# Altium schematic sheet [3]USB.SchDoc([3]USB)
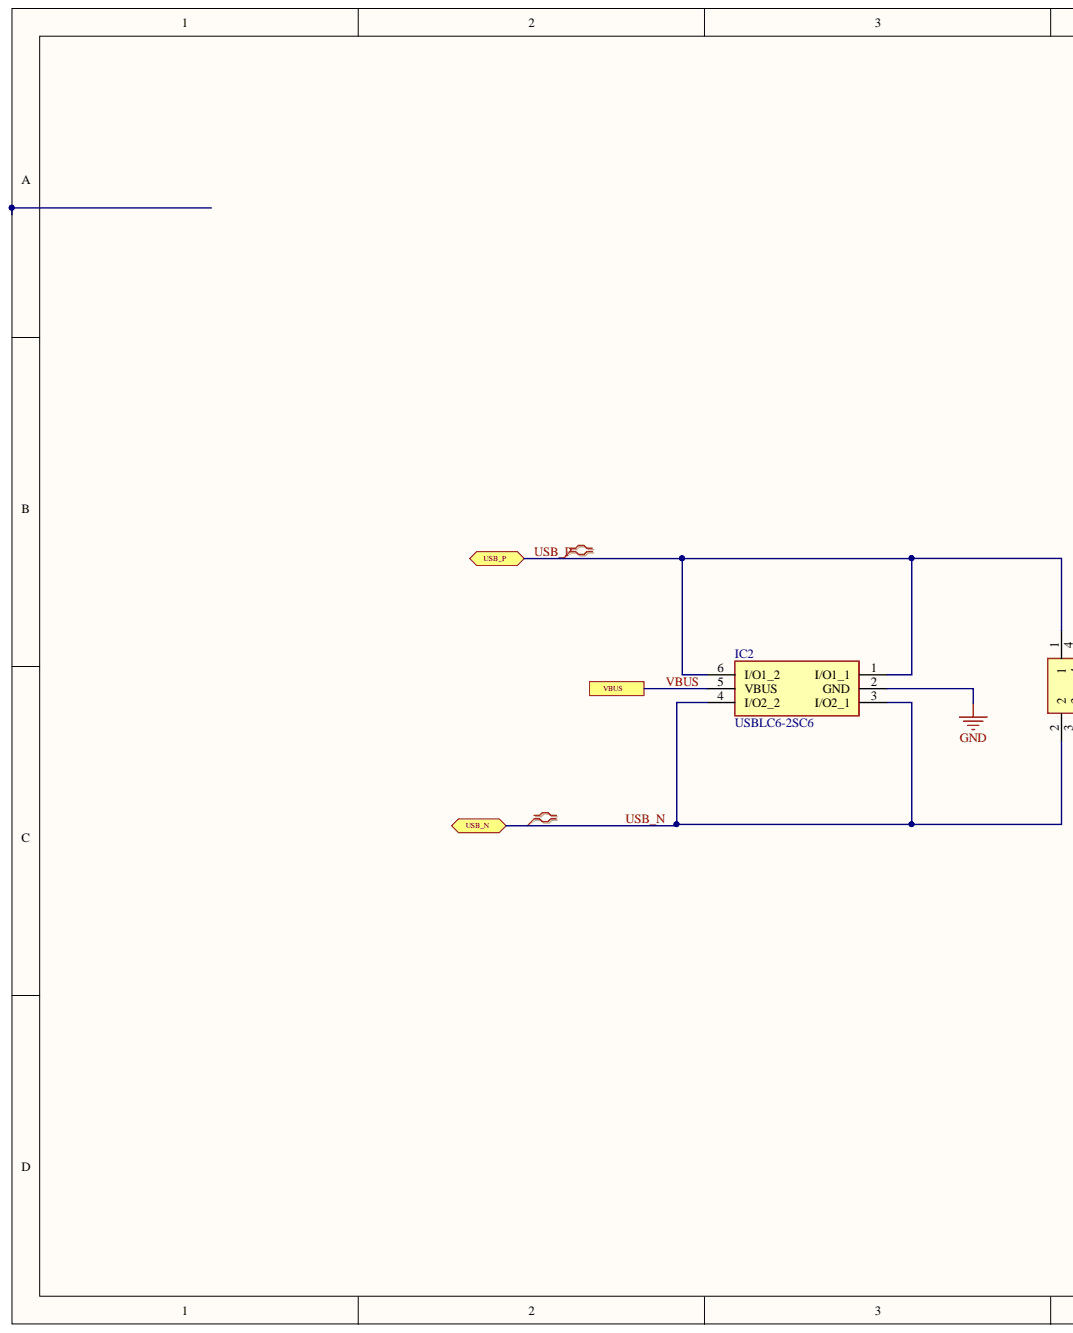
[diagram p0: [3]USB.SchDoc, left]
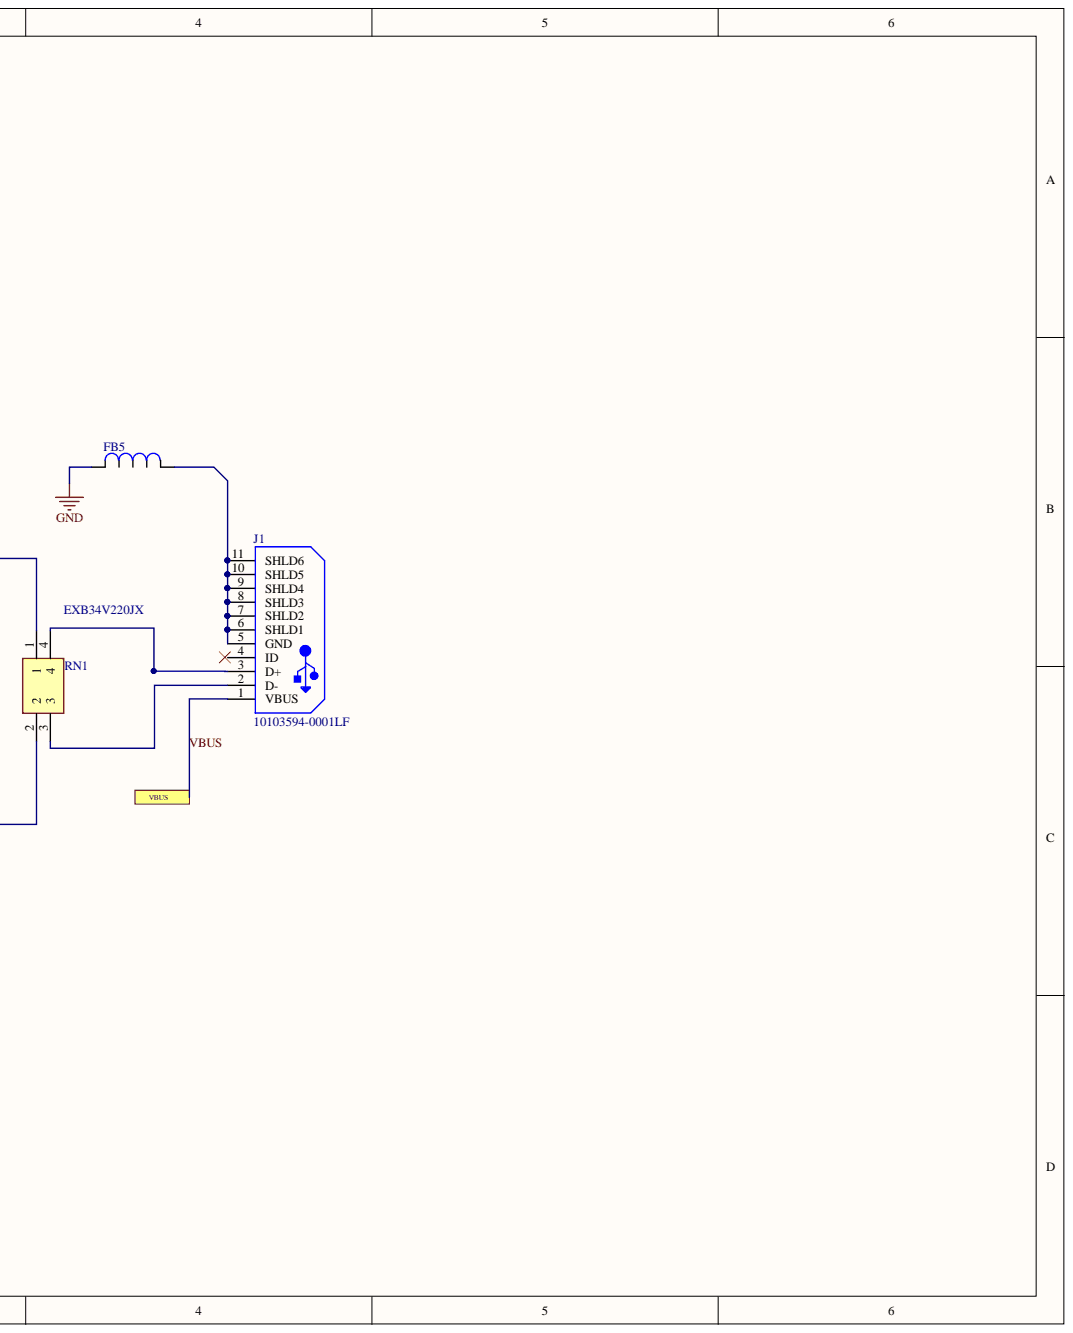
[diagram p1: [3]USB.SchDoc, right]

## Parts
FB5  Ferrite Bead  [Ferrite Bead]  (value not drawn)
IC2  USBLC6-2SC6  [SOT95P280X145-6N]
J1  10103594-0001LF  [FCI-10103594-0001LF_V]
RN1  EXB34V220JX  [EXB34V103JV]

## Ports
USB_N, USB_P, VBUS

## Nets
GND: FB5.2 IC2.2(GND)
USB_N: IC2.3(I/O2_1) IC2.4(I/O2_2) RN1.2 ; port USB_N
USB_P: IC2.1(I/O1_1) IC2.6(I/O1_2) RN1.1 ; port USB_P
VBUS: IC2.5(VBUS) J1.1(VBUS) ; port VBUS
NetFB5_1: FB5.1 J1.5(GND) J1.6(SHLD1) J1.7(SHLD2) J1.8(SHLD3) J1.9(SHLD4) J1.10(SHLD5) J1.11(SHLD6)
NetJ1_2: J1.2(D-) RN1.3
NetJ1_3: J1.3(D+) RN1.4
N00061:

Not connected: J1 4(ID)

## Library data of the parts
FB5 = Ferrite Bead: Ferrite Bead 1KOhm @100MHz; PN: BLM18AG102SN1D; Supplier Part Number 1: 81-BLM11A102S; Supplier 1: Mouser; Library Name: MasterLib.SchLib; Datasheet: https://www.murata.com/en-us/products/productdata/8796738650142/ENFA0003.pdf?src-supplier=Digi-Key; Component Kind: Standard
IC2 = USBLC6-2SC6: Integrated Circuit; Manufacturer_Name: STMicroelectronics; Mouser Price/Stock: https://www.mouser.co.uk/ProductDetail/STMicroelectronics/USBLC6-2SC6/?qs=po45yt2pPpu%2FhNIlwQdTlg%3D%3D; Mouser Part Number: 511-USBLC6-2SC6; Manufacturer_Part_Number: USBLC6-2SC6; Height: 1.45mm; Datasheet Link: http://www.st.com/st-web-ui/static/active/en/resource/technical/document/datasheet/CD00050750.pdf; Component Kind: Standard; Arrow Price/Stock: https://www.arrow.com/en/products/usblc6-2sc6/stmicroelectronics; Arrow Part Number: USBLC6-2SC6
J1 = CMP-002-00038-1: Micro USB 2.0 B Receptacle, 5 Position, Height 3.24 mm, -55 to 85 degC, RoHS, Tape and Reel; Manufacturer: FCI Electronics; Voltage Rating: 100V; Gender: Female; Current Rating: 1.8A; RoHS Compliant: True; Pins: 5; Packaging: TapeandReel; Package Reference: FCI-10103594-0001LF; Package Quantity: 1500; Package Description: 5-Pin Surface Mount Device, Body 8.04 x 5.62 mm; Mounting Technology: SM; Min Operating Temperature: -55°C; Max Operating Temperature: 85°C; Manufacturer URL: http://portal.fciconnect.com/; Lead Pitch: 0.65mm; Datasheet Version: Rev. A, 06/2010; Datasheet URL: http://portal.fciconnect.com/Comergent//fci/drawing/10103594.pdf; Contact Plating: Gold; Contact Material: Copper; Connector Type: USB; Component Kind: Standard; Case/Package: FCI-10103594-0001LF; Library Name: Latium Technologies
RN1 = EXB34V330JV: Resistor Network; Manufacturer_Name: Panasonic; Manufacturer_Part_Number: EXB34V330JV; Library Name: PCB_High_Voltage_Sensor.IntLib; Height: 0.5mm; Datasheet Link: https://industrial.panasonic.com/cdbs/www-data/pdf/AOC0000/AOC0000C14.pdf; ComponentLink1URL: https://www.mouser.ca/datasheet/2/315/AOC0000C14-1108062.pdf; ComponentLink1Description: EXB24/34V; Component Kind: Standard
